# Altium schematic sheet Rocket_Front.SchDoc
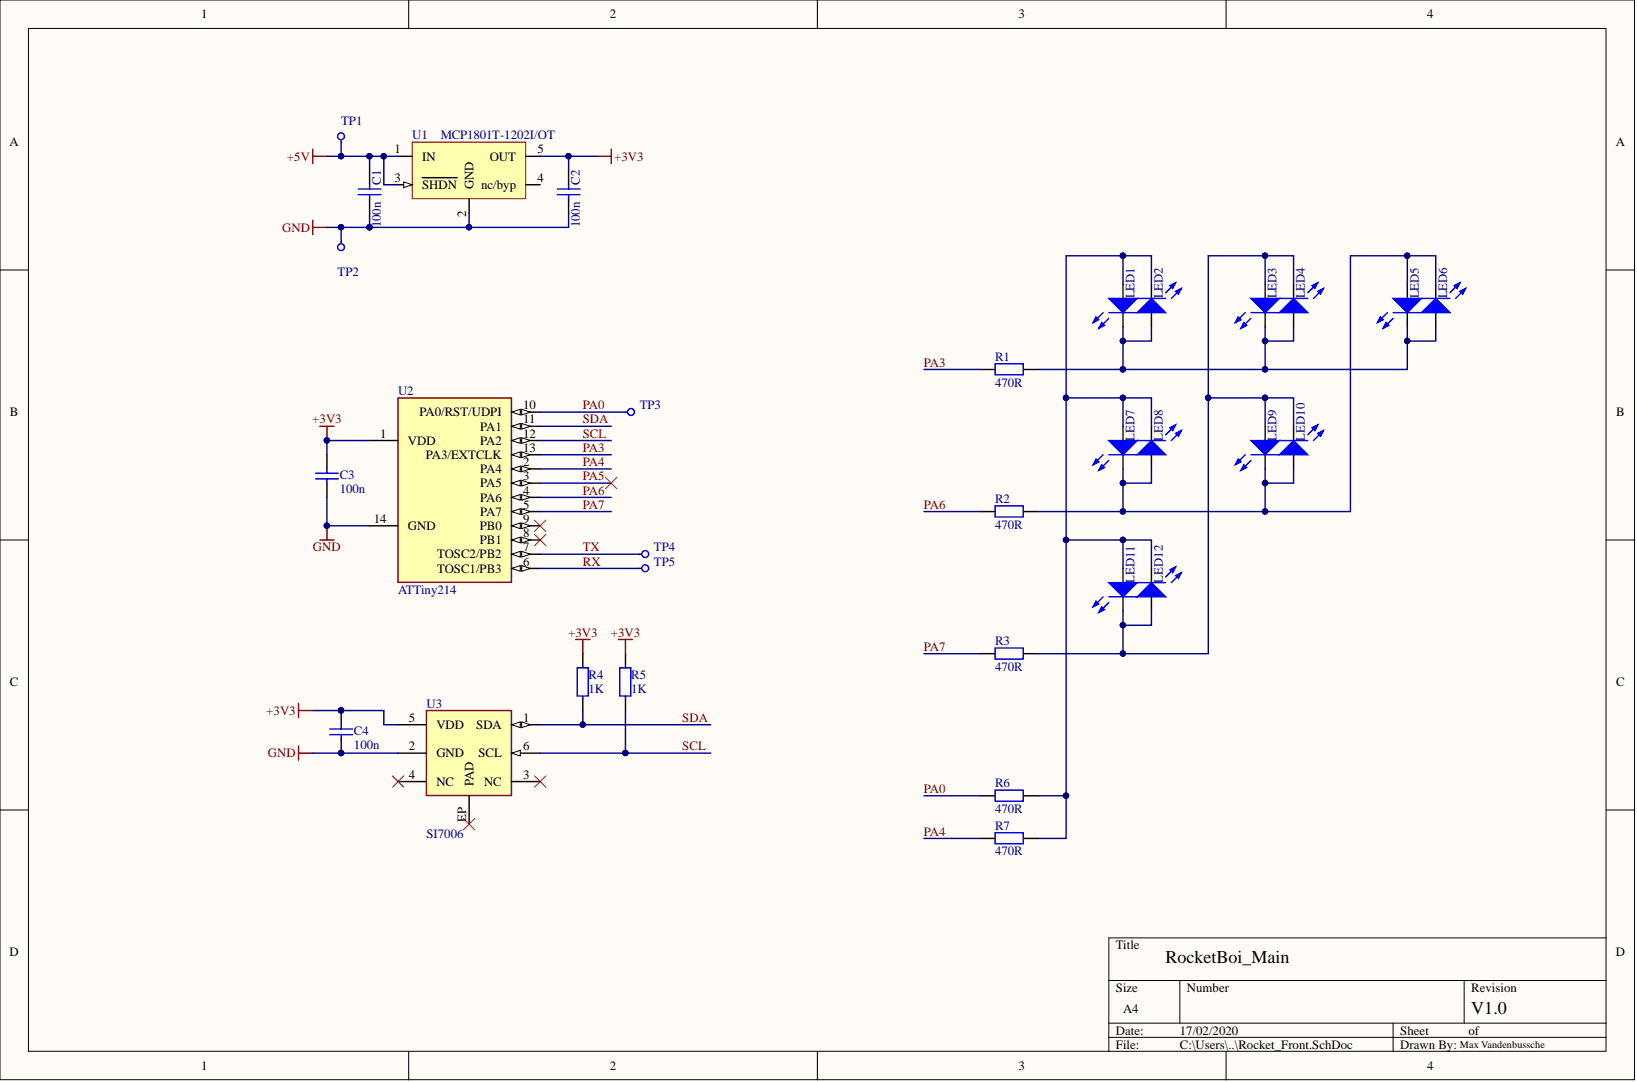
[diagram p0: Rocket_Front.SchDoc]

## Parts
C1  100n  [CAPC1608[0603]N]
C2  100n  [CAPC1608[0603]N]
C3  100n  [CAPC1608[0603]N]
C4  100n  [CAPC1608[0603]N]
LED1  SML-211DTT86K  [DIO2012[0805]]  (value not drawn)
LED2  SML-211DTT86K  [DIO2012[0805]]  (value not drawn)
LED3  SML-211DTT86K  [DIO2012[0805]]  (value not drawn)
LED4  SML-211DTT86K  [DIO2012[0805]]  (value not drawn)
LED5  SML-211DTT86K  [DIO2012[0805]]  (value not drawn)
LED6  SML-211DTT86K  [DIO2012[0805]]  (value not drawn)
LED7  SML-211DTT86K  [DIO2012[0805]]  (value not drawn)
LED8  SML-211DTT86K  [DIO2012[0805]]  (value not drawn)
LED9  SML-211DTT86K  [DIO2012[0805]]  (value not drawn)
LED10  SML-211DTT86K  [DIO2012[0805]]  (value not drawn)
LED11  SML-211DTT86K  [DIO2012[0805]]  (value not drawn)
LED12  SML-211DTT86K  [DIO2012[0805]]  (value not drawn)
R1  470R  [RESC1608[0603]N]
R2  470R  [RESC1608[0603]N]
R3  470R  [RESC1608[0603]N]
R4  1K  [RESC1608[0603]N]
R5  1K  [RESC1608[0603]N]
R6  470R  [RESC1608[0603]N]
R7  470R  [RESC1608[0603]N]
TP1  Test_Point_SMD_3mm  [SMD-Pad_3mm]  (value not drawn)  (no BOM)
TP2  Test_Point_SMD_3mm  [SMD-Pad_3mm]  (value not drawn)  (no BOM)
TP3  Test_Point_SMD_3mm  [SMD-Pad_3mm]  (value not drawn)  (no BOM)
TP4  Test_Point_SMD_3mm  [SMD-Pad_3mm]  (value not drawn)  (no BOM)
TP5  Test_Point_SMD_3mm  [SMD-Pad_3mm]  (value not drawn)  (no BOM)
U1  MCP1801T-1202I/OT  [SOT23-5]
U2  ATTiny214  [SOIC150 (SVQ)]
U3  SI7006  [3x3DFN_EP]

## Nets
+3V3: C2.2 C3.2 C4.2 R4.2 R5.2 U1.5(OUT) U2.1(VDD) U3.5(VDD)
+5V: C1.2 TP1.TP U1.1(IN) U1.3(SHDN)
GND: C1.1 C2.1 C3.1 C4.1 TP2.TP U1.2(GND) U2.14(GND) U3.2(GND)
PA0: R6.1 TP3.TP U2.10(PA0/RST/UDPI)
PA3: R1.1 U2.13(PA3/EXTCLK)
PA4: R7.1 U2.2(PA4)
PA5: U2.3(PA5)
PA6: R2.1 U2.4(PA6)
PA7: R3.1 U2.5(PA7)
RX: TP5.TP U2.6(TOSC1/PB3)
SCL: R5.1 U2.12(PA2) U3.6(SCL)
SDA: R4.1 U2.11(PA1) U3.1(SDA)
TX: TP4.TP U2.7(TOSC2/PB2)
NetLED1_1: LED1.1 LED2.2 LED3.1 LED4.2 LED5.1 LED6.2 R1.2
NetLED1_2: LED1.2 LED2.1 LED7.2 LED8.1 LED11.2 LED12.1 R6.2 R7.2
NetLED3_2: LED3.2 LED4.1 LED9.2 LED10.1 LED11.1 LED12.2 R3.2
NetLED5_2: LED5.2 LED6.1 LED7.1 LED8.2 LED9.1 LED10.2 R2.2

Not connected: U1 4(nc/byp); U2 8(PB1) 9(PB0); U3 3(NC) 4(NC) EP(PAD)

## Library data of the parts
C1-C4 = CAPC_100n_0603: CAPACITOR Ceramic Multi-Layer X7R; 100nF; 50V; 0603; Tolerance, +/-10%; Temp, op. -55°C to +125°C; Manufacturer: Samsung; Manufacturer P/N: CL10B104KBNC; AMOUNT: 1.000; CIN: 121-100-067; Delivery-XPEQT: No; Fast Track: Yes; Value: 100000p; Component Kind: Standard; ComponentLink1Description: Datasheet [PDF]; ComponentLink1URL: http://cvs.tess.elex.be/cgi-bin/cvsweb/~checkout~/business_units/technology_development/HW_Groups/datasheets/Capacitors/SMD_Ceramic/smccp.pdf?rev=1.1; FMEA_TYPE: CAPACITOR; Library Name: CapacitorCer0603.IntLib; MLX P/N: CAPC_100n_0603; Published: 2007-07-31; Signal Integrity: Cap; Simulation: CAP; Supplier 1: Comet Electronics; Supplier Part Number 1: C0603 100nF 50V X7R SAMSUNG; Voltage: 50V; Publisher: PDS
LED1-LED12 = SML-211DTT86K: LED SMD 0805, Orange, 1.25mm x 1.4mm, 608 nm, 1.8 V, 2 mA, 2.5 mcd; Manufacturer: ROHM; AMOUNT: 1.000; CIN: 000-000-000; Delivery-XPEQT: No; Component Kind: Standard; ComponentLink1Description: Datasheet; ComponentLink1URL: http://cvs.tess.elex.be/cgi-bin/cvsweb/~checkout~/business_units/technology_development/HW_Groups/datasheets/Exotic/SML-211_Series.pdf?rev=1.1; Fast Track: No; FMEA_TYPE: LED; Manufacturer P/N: SML-211DTT86K; MLX P/N: SML-211DTT86K; Published: 2016-03-30; Supplier 1: Farnell; Supplier Part Number 1: 1685055; Library Name: Semiconductor_Discrete_Exotic.IntLib; Publisher: MKK
R1-R3 R6 R7 = RESC_470R_0603: Resistor Thick Film; 470R; 0603; 0.1W; 1%; 50V; 100ppm/DegC; AMOUNT: 1.000; CIN: 122-110-324; Delivery-XPEQT: No; Component Kind: Standard; ComponentLink1Description: Datasheet [PDF]; ComponentLink1URL: http://cvs.tess.elex.be/cgi-bin/cvsweb/~checkout~/business_units/technology_development/HW_Groups/datasheets/Resistors/SMD/SMD_Resistors.pdf?rev=1.1; Fast Track: No; FMEA_TYPE: RESISTOR; Library Name: Resistor0603.IntLib; MLX P/N: RESC_470R_0603; Published: 2008-04-17; Signal Integrity: Res; Simulation: RESISTOR; Tolerance: 1%; Value: 470R; Publisher: PDS
R4 R5 = RESC_1K_0603: Resistor Thick Film; 1K; 0603; 0.1W; 1%; 50V; 100ppm/DegC; AMOUNT: 1.000; CIN: 122-110-174; Delivery-XPEQT: No; Value: 1000R; Component Kind: Standard; ComponentLink1Description: Datasheet [PDF]; ComponentLink1URL: http://cvs.tess.elex.be/cgi-bin/cvsweb/~checkout~/business_units/technology_development/HW_Groups/datasheets/Resistors/SMD/SMD_Resistors.pdf?rev=1.1; Fast Track: No; FMEA_TYPE: RESISTOR; Library Name: Resistor0603.IntLib; MLX P/N: RESC_1K_0603; Published: 2008-04-17; Signal Integrity: Res; Simulation: RESISTOR; Supplier 1: Comet Electronics; Supplier Part Number 1: R0603 1.0K 1%; Tolerance: 1%; Publisher: PDS
TP1-TP5 = Test_Point_SMD_3mm: AMOUNT: 1.000; CIN: 000-000-000; Delivery-XPEQT: No; FMEA_TYPE: MISCELLANEOUS; Description: Test_Point_SMD_3mm; Fast Track: No; Library Name: Miscellaneous.IntLib; MLX P/N: Test_Point_SMD_3mm; Published: 2017-06-15; Publisher: MKK
U1 = MCP1801T-1202I/OT: Voltage Regulator, FIxed LDO, Vo=+1.2V, Io=150mA, SOT23-5; Manufacturer: MICROCHIP; AMOUNT: 1.000; CIN: 112-160-146; Delivery-XPEQT: No; FMEA_TYPE: IC; MLX_Device: Fandriver; Component Kind: Standard; ComponentLink1Description: Datasheet [PDF]; ComponentLink1URL: https://gitlab.melexis.com/tcc-hardware/HW_Templates_Altium/AD_Datasheets/blob/master/MLX_Devices/MCP1801.pdf; Fast Track: No; Library Name: MLX_Devices.IntLib; Manufacturer P/N: MCP1801T-1202I/OT; MLX P/N: MCP1801T-1202I/OT; Published: 2019-04-18; Supplier 1: Farnell; Supplier Part Number 1: 1851980; Publisher: DTL
U2 = ATTINY214: AVR® Microcontroller with Core Independent Peripherals  and picoPower® Technology; Component Kind: Standard; Library Name: Melexis_bot.IntLib
U3 = SI7006: I2C HUMIDITY AND TEMPERATURE SENSOR; Component Kind: Standard; Library Name: Melexis_bot.IntLib
